# Altium schematic sheet Power.SchDoc(PW)
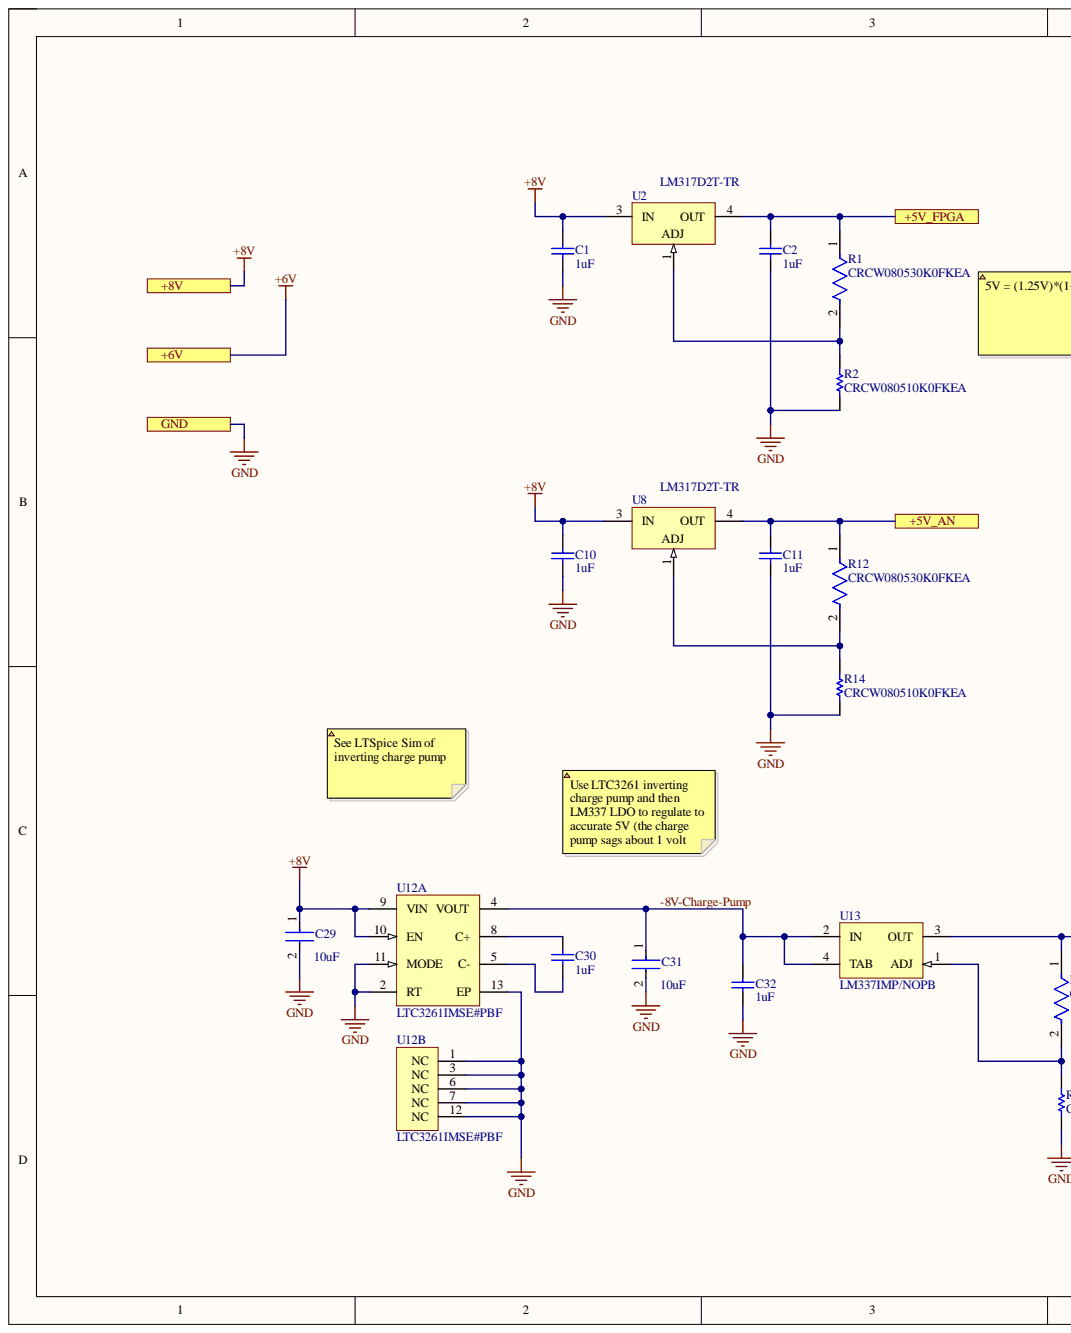
[diagram p0: Power.SchDoc, left]
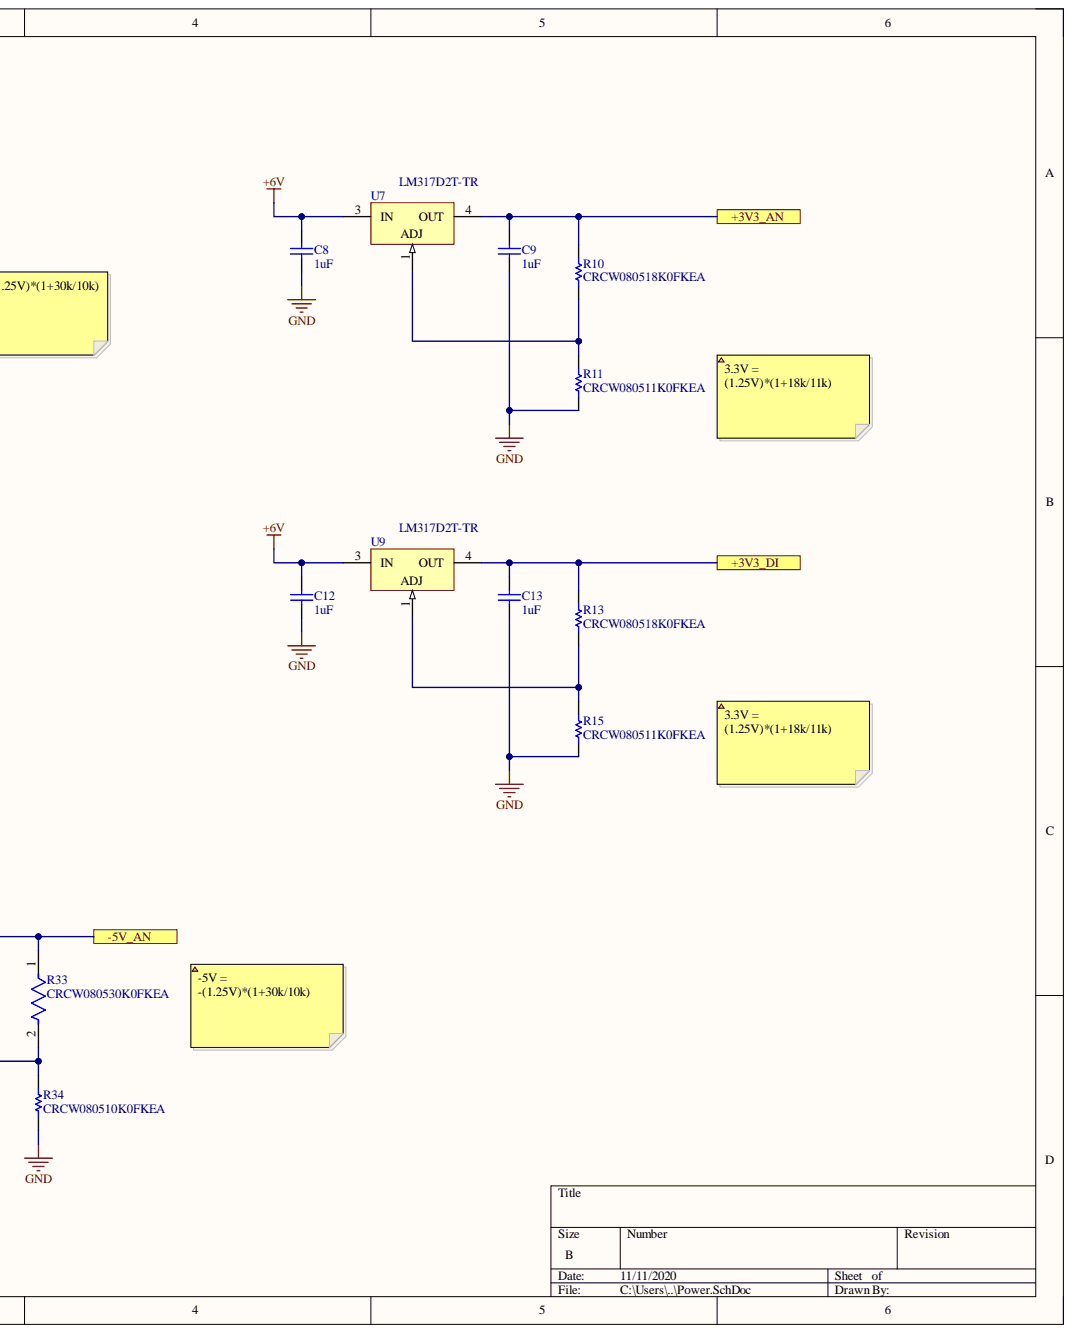
[diagram p1: Power.SchDoc, right]

## Parts
C1  1uF  [CAPC2013X100X50NL20T25]
C2  1uF  [CAPC2013X100X50NL20T25]
C8  1uF  [CAPC2013X100X50NL20T25]
C9  1uF  [CAPC2013X100X50NL20T25]
C10  1uF  [CAPC2013X100X50NL20T25]
C11  1uF  [CAPC2013X100X50NL20T25]
C12  1uF  [CAPC2013X100X50NL20T25]
C13  1uF  [CAPC2013X100X50NL20T25]
C29  Capacitance: 10uF  [FP-CGA5L-0_3-0_1-MFG]  (hidden comment: CGA5L1X7R1E106K160AC)
C30  1uF  [CAPC2013X100X50NL20T25]
C31  Capacitance: 10uF  [FP-CGA5L-0_3-0_1-MFG]  (hidden comment: CGA5L1X7R1E106K160AC)
C32  1uF  [CAPC2013X100X50NL20T25]
R1  CRCW080530K0FKEA  [FP-CRCW0805-e3-MFG]
R2  CRCW080510K0FKEA  [RESC2013X50X30NL20T20]
R10  CRCW080518K0FKEA  [RESC2013X50X30NL20T20]
R11  CRCW080511K0FKEA  [RESC2013X50X30NL20T20]
R12  CRCW080530K0FKEA  [FP-CRCW0805-e3-MFG]
R13  CRCW080518K0FKEA  [RESC2013X50X30NL20T20]
R14  CRCW080510K0FKEA  [RESC2013X50X30NL20T20]
R15  CRCW080511K0FKEA  [RESC2013X50X30NL20T20]
R33  CRCW080530K0FKEA  [FP-CRCW0805-e3-MFG]
R34  CRCW080510K0FKEA  [RESC2013X50X30NL20T20]
U2  LM317D2T-TR  [TO-263_N]
U7  LM317D2T-TR  [TO-263_N]
U8  LM317D2T-TR  [TO-263_N]
U9  LM317D2T-TR  [TO-263_N]
U12  LTC3261IMSE#PBF  [LT-MSE-12-EDP_M]  (parts A,B)
U13  LM337IMP/NOPB  [DCY0004A_L]

## Ports
+3V3_AN, +3V3_DI, +5V_AN, +5V_FPGA, +6V, +8V, -5V_AN, GND

## Nets
+3V3_AN: C9.2 R10.2 U7.4 ; port +3V3_AN
+3V3_DI: C13.2 R13.2 U9.4 ; port +3V3_DI
+5V_FPGA: C2.2 R1.1 U2.4 ; port +5V_FPGA
+6V: C8.2 C12.2 U7.3 U9.3 ; port +6V
+8V: C1.2 C10.2 C29.1 U2.3 U8.3 U12.9(VIN) U12.10(EN) ; port +8V
-8V-Charge-Pump: C31.1 C32.2 U12.4(VOUT) U13.2(IN) U13.4(TAB)
GND: C1.1 C2.1 C8.1 C9.1 C10.1 C11.1 C12.1 C13.1 C29.2 C31.2 C32.1 R2.2 R11.1 R14.2 R15.1 R34.2 U12.1(NC) U12.2(RT) U12.3(NC) U12.6(NC) U12.7(NC) U12.11(MODE) U12.12(NC) U12.13(EP) ; port GND
NetC11_2: C11.2 R12.1 U8.4
NetC30_1: C30.1 U12.5(C-)
NetC30_2: C30.2 U12.8(C+)
NetR1_2: R1.2 R2.1 U2.1
NetR10_1: R10.1 R11.2 U7.1
NetR12_2: R12.2 R14.1 U8.1
NetR13_1: R13.1 R15.2 U9.1
NetR33_1: R33.1 U13.3(OUT)
NetR33_2: R33.2 R34.1 U13.1(ADJ)

## Library data of the parts
C1 C2 C8-C13 C30 C32 = CMP-2007-03716-1: Manufacturer: Kemet; Part Number: C0805X105K3RACTU; Component Kind: Standard; Library Name: Altium Content Vault
C29 C31 = CMP-08246-002126-1: Cap Ceramic 10uF 25V X7R 10% Pad SMD 1206 +125°C Automotive T/R; Case Code (Imperial): 1206; Case Code (Metric): 3216; Case/Package: 1206; Component Kind: Standard; Composition: Ceramic; Depth: 1.6mm; Dielectric: X7R; Height: 1.6mm; Lead Free: Lead Free; Length: 3.2mm; Library Name: Altium Content Vault; Max Operating Temperature: 125°C; Min Operating Temperature: -55°C; Mount: Surface Mount; Package Quantity: 1; Packaging: Tape and Reel; Ratings: AEC-Q200; REACH SVHC: No SVHC; Termination: SMD/SMT; Thickness: 0.075inch; Tolerance: 10%; Voltage Rating: 25V; Voltage Rating (DC): 25V; Weight: 0.000571oz; Width: 0.063inch
R1 R12 R33 = CMP-02407-008264-1: RES Thick Film, 30kΩ, 1%, 0.125W, 100ppm/°C, 0805; Max Power Dissipation: 125mW; Resistance: 30kR; Voltage Rating: 150V; Case Code (Imperial): 0805; Case Code (Metric): 2012; Case/Package: 0805; Component Kind: Standard; Composition: Thick Film; Contact Plating: Tin; Depth: 1.25mm; Features: Automotive AEC-Q200; Height: 0.45mm; Lead Free: Lead Free; Length: 2mm; Library Name: Altium Content Vault; Max Operating Temperature: 155°C; Military Standard: Not; Min Operating Temperature: -55°C; Mount: Surface Mount; Number of Pins: 2; Number of Terminations: 2; Package Quantity: 10; Packaging: Tape and Reel; Power Rating: 125mW; Radiation Hardening: No; Temperature Coefficient: 100ppm/°C; Termination: SMD/SMT; Tolerance: 1%; Voltage Rating (DC): 150V; Weight: 0.000194oz; Width: 0.049inch
R2 R14 R34 = CMP-2001-04908-1: Manufacturer: Vishay Dale; Component Kind: Standard; Library Name: Altium Content Vault; Part Number: CRCW080510K0FKEA
R10 R13 = CMP-2001-03588-1: Manufacturer: Vishay Dale; Component Kind: Standard; Library Name: Altium Content Vault; Part Number: CRCW080518K0FKEA
R11 R15 = CMP-2001-04924-1: Manufacturer: Vishay Dale; Component Kind: Standard; Library Name: Altium Content Vault; Part Number: CRCW080511K0FKEA
U2 U7-U9 = CMP-0244-00094-1: 1.5A, 1.2V to 37V Adjustable Voltage Regulator, 3-Pin D2PAK, Tape and Reel; Component Kind: Standard; ComponentLink1Description: Manufacturer URL; ComponentLink1URL: http://www.st.com; ComponentLink2Description: Datasheet; ComponentLink2URL: http://www.st.com/internet/com/TECHNICAL_RESOURCES/TECHNICAL_LITERATURE/DATASHEET/CD00000455.pdf; DatasheetVersion: 1/11/2011; Library Name: Altium Content Vault; PackageDescription: 3-Pin Transistor Outline Package 2.54 mm Pitch, 15.4 mm Lead Span (D2PAK); PackageReference: TO-263; PackageVersion: 2011
U12 = CMP-0475-00250-1: High Voltage, Low Quiescent Current Inverting Charge Pump, 4.5 to 32 V Vin, 12-pin SOP (MSE-12-EDP), -40 to 125 degC, Pb-Free; Manufacturer: Linear Technology; Frequency(kHz): 500; Ishutdown(uA): 2; Isupply(mA): 0.06; Max Iout(mA): 100; Vin Max(V): 32; Vin Min(V): 4.5; Voutput: -Vin; Component Kind: Standard; ComponentLink1Description: Manufacturer URL; ComponentLink1URL: http://www.linear.com/; ComponentLink2Description: Datasheet; ComponentLink2URL: http://cds.linear.com/docs/en/datasheet/3261fb.pdf; ComponentLink3Description: Package Specification; ComponentLink3URL: http://cds.linear.com/docs/en/packaging/05081666_G_MSE12.pdf; DatasheetVersion: Rev. B; Library Name: Altium Content Vault; Mounting Technology: Surface Mount; PackageDescription: 12-Pin Plastic MSOP, Exposed Die Pad, Body 4.039 x 3 mm, Pitch 0.65 mm; PackageReference: MSE-12-EDP; PackageVersion: Rev. G, 05/2013; PartNumber: LTC3261IMSE#PBF; RoHS: TRUE
U13 = CMP-0062-01832-3: 3-Terminal Adjustable Negative Regulator, 4-pin SOT-223, Pb-Free; Manufacturer: Texas Instruments; CompType: LDO IC; PackageTopMarking: XYTT*N02A; PowerWise: N; Code_IPC: SOT223-4; Code_JEDEC: TO-261-AA; Component Kind: Standard; ComponentLink1Description: Datasheet URL; ComponentLink1URL: http://www.ti.com/general/docs/lit/getliterature.tsp?genericPartNumber=LM337-N&fileType=pdf; Library Name: Altium Content Vault; PackageDescription: Molded SOT-223, 6.50 x 3.56 x 1.6mm Body, 4 Lead, 2.3mm Pitch; PackageReference: DCY0004A; PinCount: 4; RoHS: Y
